# Altium schematic sheet 01_fpga.SchDoc
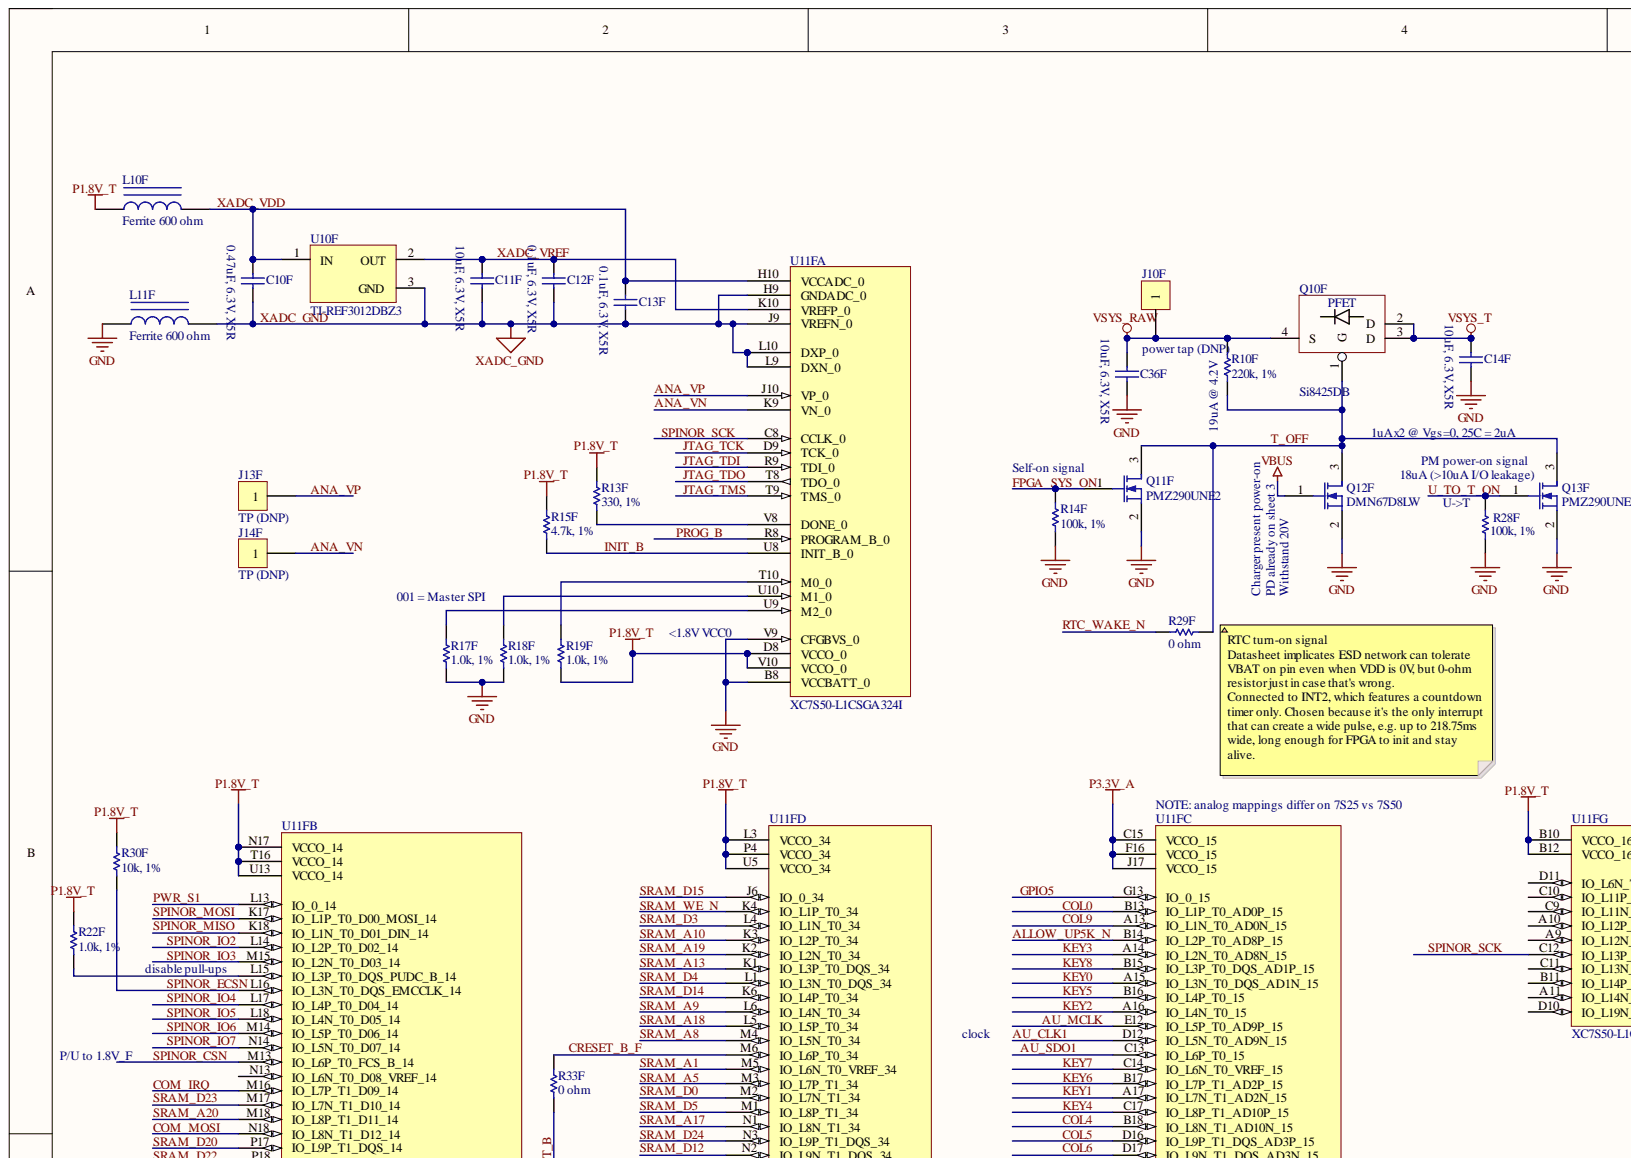
[diagram p0: 01_fpga.SchDoc, top left]
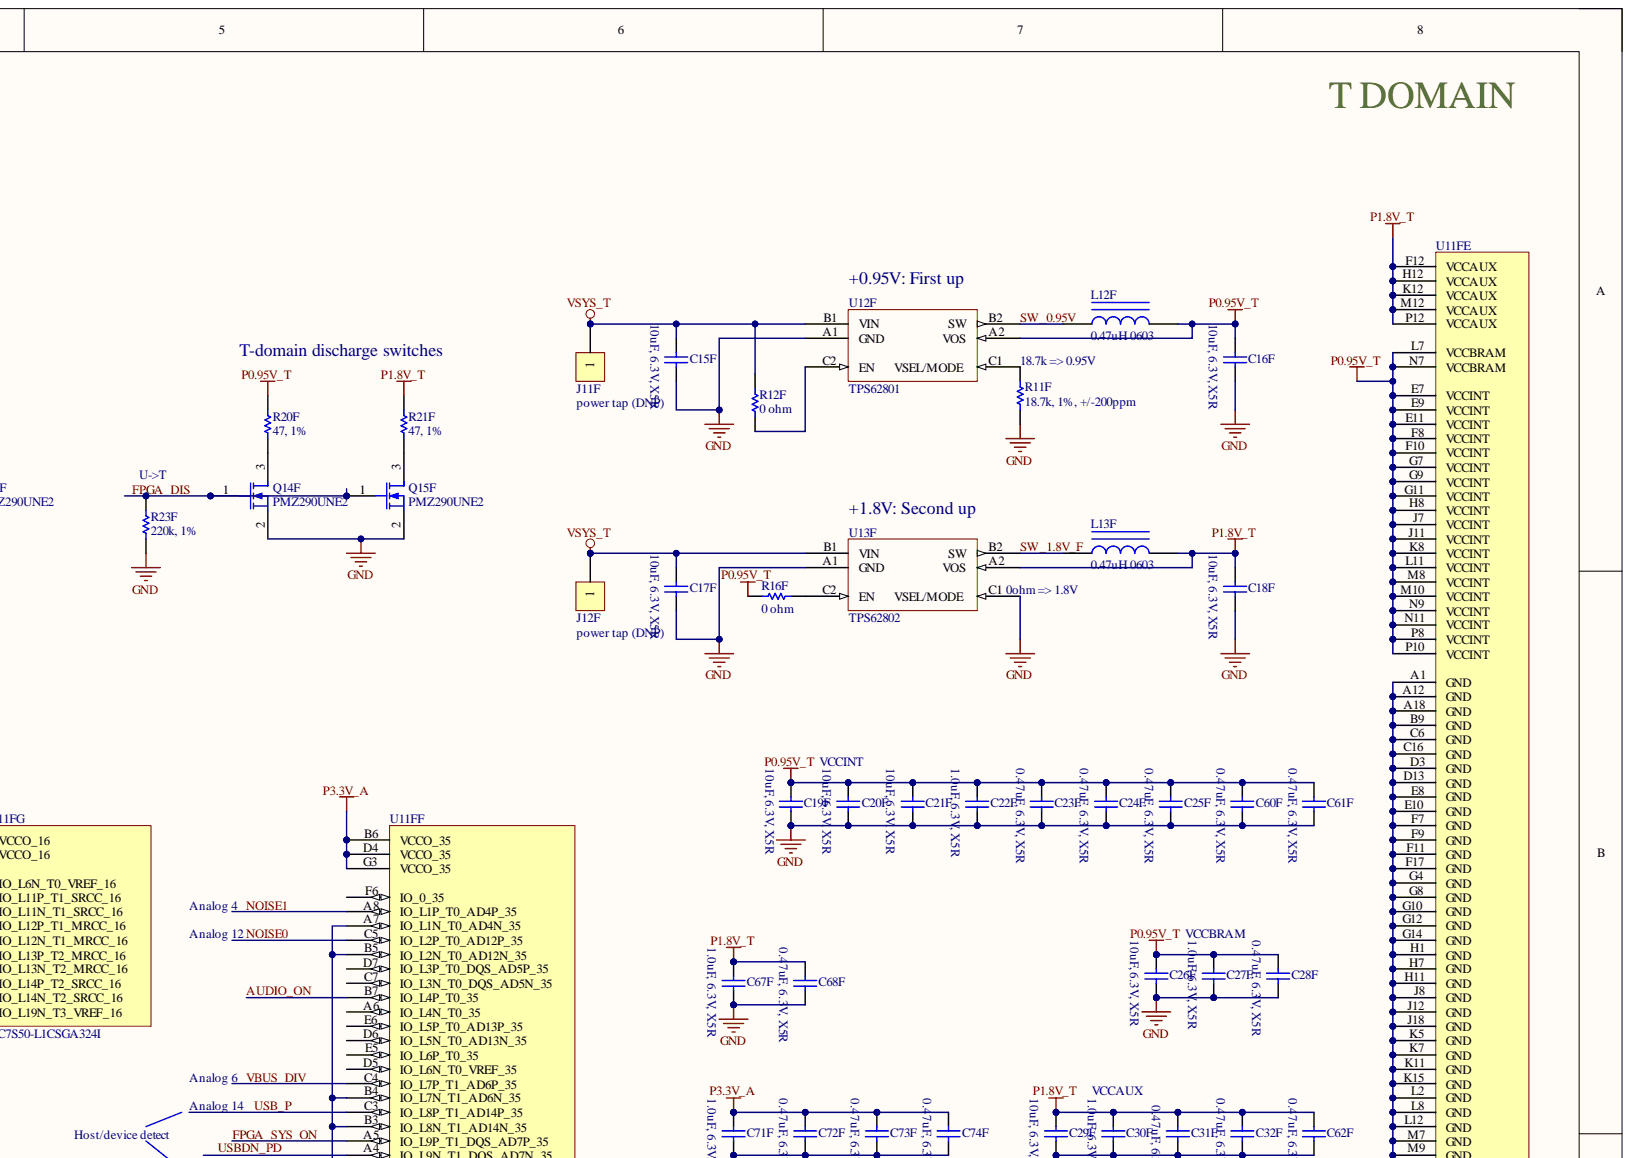
[diagram p1: 01_fpga.SchDoc, top right]
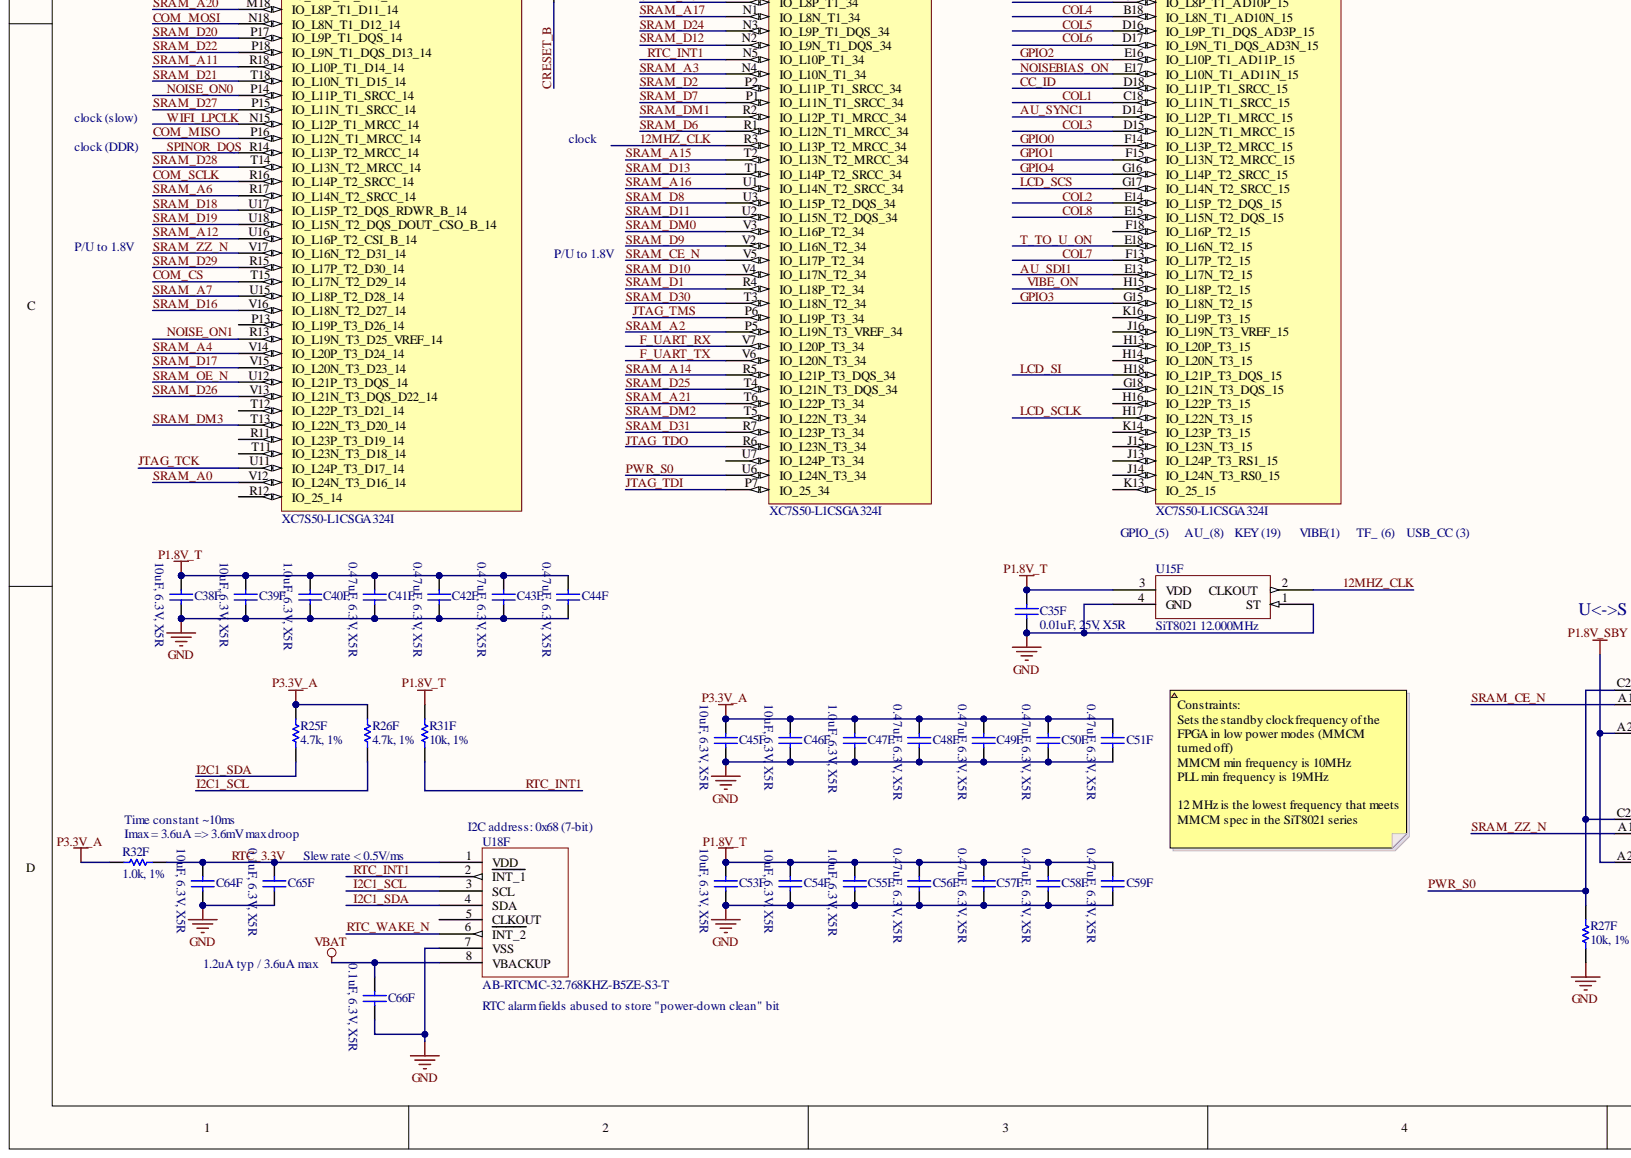
[diagram p2: 01_fpga.SchDoc, bottom left]
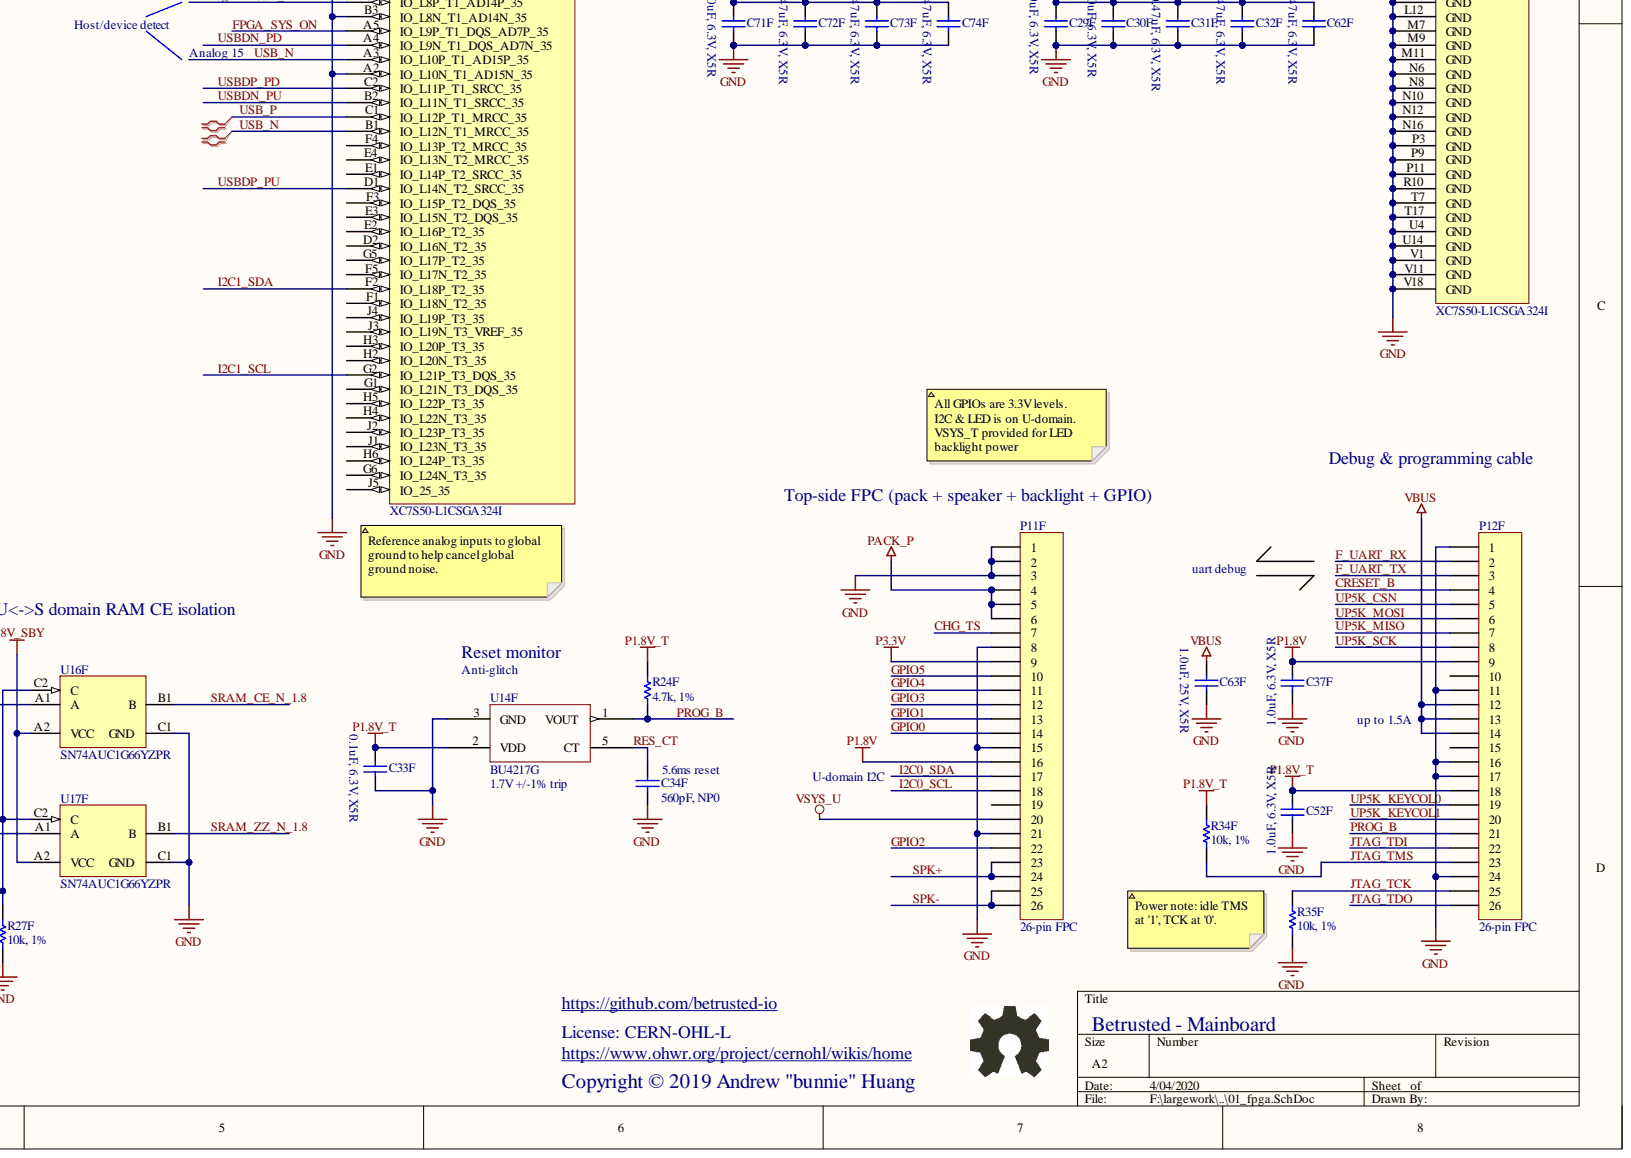
[diagram p3: 01_fpga.SchDoc, bottom right]

## Parts
C10F  0.47uF, 6.3V, X5R  [B_CAP0201]
C11F  10uF, 6.3V, X5R  [B_CAP0603]
C12F  0.1uF, 6.3V, X5R  [B_CAP0201]
C13F  0.1uF, 6.3V, X5R  [B_CAP0201]
C14F  10uF, 6.3V, X5R  [B_CAP0603]
C15F  10uF, 6.3V, X5R  [B_CAP0603]
C16F  10uF, 6.3V, X5R  [B_CAP0603]
C17F  10uF, 6.3V, X5R  [B_CAP0603]
C18F  10uF, 6.3V, X5R  [B_CAP0603]
C19F  10uF, 6.3V, X5R  [B_CAP0603]
C20F  10uF, 6.3V, X5R  [B_CAP0603]
C21F  10uF, 6.3V, X5R  [B_CAP0603]
C22F  1.0uF, 6.3V, X5R  [B_CAP0201]
C23F  0.47uF, 6.3V, X5R  [B_CAP0201]
C24F  0.47uF, 6.3V, X5R  [B_CAP0201]
C25F  0.47uF, 6.3V, X5R  [B_CAP0201]
C26F  10uF, 6.3V, X5R  [B_CAP0603]
C27F  1.0uF, 6.3V, X5R  [B_CAP0201]
C28F  0.47uF, 6.3V, X5R  [B_CAP0201]
C29F  10uF, 6.3V, X5R  [B_CAP0603]
C30F  1.0uF, 6.3V, X5R  [B_CAP0201]
C31F  0.47uF, 6.3V, X5R  [B_CAP0201]
C32F  0.47uF, 6.3V, X5R  [B_CAP0201]
C33F  0.1uF, 6.3V, X5R  [B_CAP0201]
C34F  560pF, NP0  [B_RES0201]
C35F  0.01uF, 25V, X5R  [B_RES0201]
C36F  10uF, 6.3V, X5R  [B_CAP0603]
C37F  1.0uF, 6.3V, X5R  [B_CAP0201]
C38F  10uF, 6.3V, X5R  [B_CAP0603]
C39F  10uF, 6.3V, X5R  [B_CAP0603]
C40F  1.0uF, 6.3V, X5R  [B_CAP0201]
C41F  0.47uF, 6.3V, X5R  [B_CAP0201]
C42F  0.47uF, 6.3V, X5R  [B_CAP0201]
C43F  0.47uF, 6.3V, X5R  [B_CAP0201]
C44F  0.47uF, 6.3V, X5R  [B_CAP0201]
C45F  10uF, 6.3V, X5R  [B_CAP0603]
C46F  10uF, 6.3V, X5R  [B_CAP0603]
C47F  1.0uF, 6.3V, X5R  [B_CAP0201]
C48F  0.47uF, 6.3V, X5R  [B_CAP0201]
C49F  0.47uF, 6.3V, X5R  [B_CAP0201]
C50F  0.47uF, 6.3V, X5R  [B_CAP0201]
C51F  0.47uF, 6.3V, X5R  [B_CAP0201]
C52F  1.0uF, 6.3V, X5R  [B_CAP0201]
C53F  10uF, 6.3V, X5R  [B_CAP0603]
C54F  10uF, 6.3V, X5R  [B_CAP0603]
C55F  1.0uF, 6.3V, X5R  [B_CAP0201]
C56F  0.47uF, 6.3V, X5R  [B_CAP0201]
C57F  0.47uF, 6.3V, X5R  [B_CAP0201]
C58F  0.47uF, 6.3V, X5R  [B_CAP0201]
C59F  0.47uF, 6.3V, X5R  [B_CAP0201]
C60F  0.47uF, 6.3V, X5R  [B_CAP0201]
C61F  0.47uF, 6.3V, X5R  [B_CAP0201]
C62F  0.47uF, 6.3V, X5R  [B_CAP0201]
C63F  1.0uF, 25V, X5R  [B_CAP0603]
C64F  10uF, 6.3V, X5R  [B_CAP0603]
C65F  0.1uF, 6.3V, X5R  [B_CAP0201]
C66F  0.1uF, 6.3V, X5R  [B_CAP0201]
C67F  1.0uF, 6.3V, X5R  [B_CAP0201]
C68F  0.47uF, 6.3V, X5R  [B_CAP0201]
C71F  1.0uF, 6.3V, X5R  [B_CAP0201]
C72F  0.47uF, 6.3V, X5R  [B_CAP0201]
C73F  0.47uF, 6.3V, X5R  [B_CAP0201]
C74F  0.47uF, 6.3V, X5R  [B_CAP0201]
J10F  power tap (DNP)  [RESTAP-0603]
J11F  power tap (DNP)  [RESTAP-0603]
J12F  power tap (DNP)  [RESTAP-0603]
J13F  TP (DNP)  [TP_0.4x0.4_SMT]
J14F  TP (DNP)  [TP_0.4x0.4_SMT]
L10F  Ferrite 600 ohm  [B_IND0603]
L11F  Ferrite 600 ohm  [B_IND0603]
L12F  0.47uH 0603  [B_IND0603]
L13F  0.47uH 0603  [B_IND0603]
P11F  26-pin FPC  [HRS_FH34SRJ-26S-0.5SH(50)]
P12F  26-pin FPC  [HRS_FH34SRJ-26S-0.5SH(50)]
Q10F  Si8425DB  [VISHAY_MICRO_FOOT_4_BUMP]
Q11F  PMZ290UNE2  [SOT883]
Q12F  DMN67D8LW  [SOT323-3AN]
Q13F  PMZ290UNE2  [SOT883]
Q14F  PMZ290UNE2  [SOT883]
Q15F  PMZ290UNE2  [SOT883]
R10F  220k, 1%  [B_RES0201]
R11F  18.7k, 1%, +/-200ppm  [B_RES0402]
R12F  0 ohm  [B_RES0201]
R13F  330, 1%  [B_RES0201]
R14F  100k, 1%  [B_RES0201]
R15F  4.7k, 1%  [B_RES0201]
R16F  0 ohm  [B_RES0201]
R17F  1.0k, 1%  [B_RES0201]
R18F  1.0k, 1%  [B_RES0201]
R19F  1.0k, 1%  [B_RES0201]
R20F  47, 1%  [B_RES0402]
R21F  47, 1%  [B_RES0402]
R22F  1.0k, 1%  [B_RES0201]
R23F  220k, 1%  [B_RES0201]
R24F  4.7k, 1%  [B_RES0201]
R25F  4.7k, 1%  [B_RES0201]
R26F  4.7k, 1%  [B_RES0201]
R27F  10k, 1%  [B_RES0201]
R28F  100k, 1%  [B_RES0201]
R29F  0 ohm  [B_RES0201]
R30F  10k, 1%  [B_RES0201]
R31F  10k, 1%  [B_RES0201]
R32F  1.0k, 1%  [B_RES0201]
R33F  0 ohm  [B_RES0201]
R34F  10k, 1%  [B_RES0201]
R35F  10k, 1%  [B_RES0201]
U10F  TI-REF3012DBZ3  [ONSC-SOT-23-3-318-08_V]
U11F  XC7S50-L1CSGA324I  [BGA324C80P18X18_1500X1500X150]  (parts A,B,C,D,E,F,G)
U12F  TPS62801  [BGA6C35P2X3_70X105X40_NSMD]
U13F  TPS62802  [BGA6C35P2X3_70X105X40_NSMD]
U14F  BU4217G  [SOT23-5AN]
U15F  SiT8021 12.000MHz  [SiTIME_CSP]
U16F  SN74AUC1G66YZPR  [YZP0005ADAD]
U17F  SN74AUC1G66YZPR  [YZP0005ADAD]
U18F  AB-RTCMC-32.768KHZ-B5ZE-S3-T  [ABRACON_RTCMC_LGA10]

## Nets
GND: C14F.2 C15F.2 C16F.2 C17F.2 C18F.2 C19F.2 C20F.2 C21F.2 C22F.1 C23F.2 C24F.2 C25F.2 C26F.2 C27F.1 C28F.2 C29F.2 C30F.1 C31F.2 C32F.2 C33F.2 C34F.2 C35F.2 C36F.2 C37F.1 C38F.2 C39F.2 C40F.1 C41F.2 C42F.2 C43F.2 C44F.2 C45F.2 C46F.2 C47F.1 C48F.2 C49F.2 C50F.2 C51F.2 C52F.1 C53F.2 C54F.2 C55F.1 C56F.2 C57F.2 C58F.2 C59F.2 C60F.2 C61F.2 C62F.2 C63F.2 C64F.2 C65F.2 C66F.2 C67F.1 C68F.2 C71F.1 C72F.2 C73F.2 C74F.2 L11F.1 P11F.1 P11F.2 P11F.3 P11F.8 P11F.15 P11F.21 P12F.1 P12F.11 P12F.16 P12F.17 P12F.24 Q11F.2 Q12F.2 Q13F.2 Q14F.2 Q15F.2 R11F.2 R14F.1 R17F.1 R18F.1 R23F.1 R27F.2 R28F.1 R35F.2 U11F.A1(GND) U11F.A2(IO_L10N_T1_AD15N_35) U11F.A7(IO_L1N_T0_AD4N_35) U11F.A12(GND) U11F.A18(GND) U11F.B3(IO_L8N_T1_AD14N_35) U11F.B4(IO_L7N_T1_AD6N_35) U11F.B5(IO_L2N_T0_AD12N_35) U11F.B8(VCCBATT_0) U11F.B9(GND) U11F.C6(GND) U11F.C16(GND) U11F.D3(GND) U11F.D13(GND) U11F.E8(GND) U11F.E10(GND) U11F.F7(GND) U11F.F9(GND) U11F.F11(GND) U11F.F17(GND) U11F.G4(GND) U11F.G8(GND) U11F.G10(GND) U11F.G12(GND) U11F.G14(GND) U11F.H1(GND) U11F.H7(GND) U11F.H11(GND) U11F.J8(GND) U11F.J12(GND) U11F.J18(GND) U11F.K5(GND) U11F.K7(GND) U11F.K11(GND) U11F.K15(GND) U11F.L2(GND) U11F.L8(GND) U11F.L12(GND) U11F.M7(GND) U11F.M9(GND) U11F.M11(GND) U11F.N6(GND) U11F.N8(GND) U11F.N10(GND) U11F.N12(GND) U11F.N16(GND) U11F.P3(GND) U11F.P9(GND) U11F.P11(GND) U11F.R10(GND) U11F.T7(GND) U11F.T17(GND) U11F.U4(GND) U11F.U14(GND) U11F.V1(GND) U11F.V9(CFGBVS_0) U11F.V11(GND) U11F.V18(GND) U12F.A1(GND) U13F.A1(GND) U13F.C1(VSEL/MODE) U14F.3(GND) U15F.1(ST) U15F.4(GND) U16F.C1(GND) U17F.C1(GND) U18F.7(VSS)
P0.95V_T: C16F.1 C19F.1 C20F.1 C21F.1 C22F.2 C23F.1 C24F.1 C25F.1 C26F.1 C27F.2 C28F.1 C60F.1 C61F.1 L12F.2 R16F.1 R20F.2 U11F.E7(VCCINT) U11F.E9(VCCINT) U11F.E11(VCCINT) U11F.F8(VCCINT) U11F.F10(VCCINT) U11F.G7(VCCINT) U11F.G9(VCCINT) U11F.G11(VCCINT) U11F.H8(VCCINT) U11F.J7(VCCINT) U11F.J11(VCCINT) U11F.K8(VCCINT) U11F.L7(VCCBRAM) U11F.L11(VCCINT) U11F.M8(VCCINT) U11F.M10(VCCINT) U11F.N7(VCCBRAM) U11F.N9(VCCINT) U11F.N11(VCCINT) U11F.P8(VCCINT) U11F.P10(VCCINT) U12F.A2(VOS)
P1.8V: C37F.2 P11F.16 P12F.9
P1.8V_SBY: U16F.A2(VCC) U17F.A2(VCC)
P1.8V_T: C18F.1 C29F.1 C30F.2 C31F.1 C32F.1 C33F.1 C35F.1 C38F.1 C39F.1 C40F.2 C41F.1 C42F.1 C43F.1 C44F.1 C52F.2 C53F.1 C54F.1 C55F.2 C56F.1 C57F.1 C58F.1 C59F.1 C62F.1 C67F.2 C68F.1 L10F.1 L13F.2 P12F.18 R13F.2 R15F.2 R19F.1 R21F.2 R22F.2 R24F.2 R30F.1 R31F.1 R34F.1 U11F.B10(VCCO_16) U11F.B12(VCCO_16) U11F.D8(VCCO_0) U11F.F12(VCCAUX) U11F.H12(VCCAUX) U11F.K12(VCCAUX) U11F.L3(VCCO_34) U11F.M12(VCCAUX) U11F.N17(VCCO_14) U11F.P4(VCCO_34) U11F.P12(VCCAUX) U11F.T16(VCCO_14) U11F.U5(VCCO_34) U11F.U13(VCCO_14) U11F.V10(VCCO_0) U13F.A2(VOS) U14F.2(VDD) U15F.3(VDD)
P3.3V: P11F.9
P3.3V_A: C45F.1 C46F.1 C47F.2 C48F.1 C49F.1 C50F.1 C51F.1 C71F.2 C72F.1 C73F.1 C74F.1 R25F.2 R26F.2 R32F.2 U11F.B6(VCCO_35) U11F.C15(VCCO_15) U11F.D4(VCCO_35) U11F.F16(VCCO_15) U11F.G3(VCCO_35) U11F.J17(VCCO_15)
PACK_P: P11F.4 P11F.5 P11F.6
VBAT: C66F.1 U18F.8(VBACKUP)
VBUS: C63F.1 P12F.12 P12F.13 P12F.14 Q12F.1
VSYS_RAW: C36F.1 J10F.1 Q10F.4(S) R10F.2
VSYS_T: C14F.1 C15F.1 C17F.1 J11F.1 J12F.1 Q10F.2(D) Q10F.3(D) R12F.2 U12F.B1(VIN) U13F.B1(VIN)
VSYS_U: P11F.20
12MHZ_CLK: U11F.R3(IO_L13P_T2_MRCC_34) U15F.2(CLKOUT)
ALLOW_UP5K_N: U11F.B14(IO_L2P_T0_AD8P_15)
ANA_VN: J14F.1 U11F.K9(VN_0)
ANA_VP: J13F.1 U11F.J10(VP_0)
AU_CLK1: U11F.D12(IO_L5N_T0_AD9N_15)
AU_MCLK: U11F.E12(IO_L5P_T0_AD9P_15)
AU_SDI1: U11F.E13(IO_L17N_T2_15)
AU_SDO1: U11F.C13(IO_L6P_T0_15)
AU_SYNC1: U11F.D14(IO_L12P_T1_MRCC_15)
AUDIO_ON: U11F.B7(IO_L4P_T0_35)
CC_ID: U11F.D18(IO_L11P_T1_SRCC_15)
CHG_TS: P11F.7
COL0: U11F.B13(IO_L1P_T0_AD0P_15)
COL1: U11F.C18(IO_L11N_T1_SRCC_15)
COL2: U11F.E14(IO_L15P_T2_DQS_15)
COL3: U11F.D15(IO_L12N_T1_MRCC_15)
COL4: U11F.B18(IO_L8N_T1_AD10N_15)
COL5: U11F.D16(IO_L9P_T1_DQS_AD3P_15)
COL6: U11F.D17(IO_L9N_T1_DQS_AD3N_15)
COL7: U11F.F13(IO_L17P_T2_15)
COL8: U11F.E15(IO_L15N_T2_DQS_15)
COL9: U11F.A13(IO_L1N_T0_AD0N_15)
COM_CS: U11F.T15(IO_L17N_T2_D29_14)
COM_IRQ: U11F.M16(IO_L7P_T1_D09_14)
COM_MISO: U11F.P16(IO_L12N_T1_MRCC_14)
COM_MOSI: U11F.N18(IO_L8N_T1_D12_14)
COM_SCLK: U11F.R16(IO_L14P_T2_SRCC_14)
CRESET_B: P12F.4 R33F.1
CRESET_B_F: R33F.2 U11F.M6(IO_L6P_T0_34)
F_UART_RX: P12F.2 U11F.V7(IO_L20P_T3_34)
F_UART_TX: P12F.3 U11F.V6(IO_L20N_T3_34)
FPGA_DIS: Q14F.1 Q15F.1 R23F.2
FPGA_SYS_ON: Q11F.1 R14F.2 U11F.A5(IO_L9P_T1_DQS_AD7P_35)
GPIO0: P11F.14 U11F.F14(IO_L13P_T2_MRCC_15)
GPIO1: P11F.13 U11F.F15(IO_L13N_T2_MRCC_15)
GPIO2: P11F.22 U11F.E16(IO_L10P_T1_AD11P_15)
GPIO3: P11F.12 U11F.G15(IO_L18N_T2_15)
GPIO4: P11F.11 U11F.G16(IO_L14P_T2_SRCC_15)
GPIO5: P11F.10 U11F.G13(IO_0_15)
I2C0_SCL: P11F.18
I2C0_SDA: P11F.17
I2C1_SCL: R26F.1 U11F.G2(IO_L21P_T3_DQS_35) U18F.3(SCL)
I2C1_SDA: R25F.1 U11F.F2(IO_L18P_T2_35) U18F.4(SDA)
INIT_B: R15F.1 U11F.U8(INIT_B_0)
JTAG_TCK: P12F.25 R35F.1 U11F.D9(TCK_0) U11F.U11(IO_L24P_T3_D17_14)
JTAG_TDI: P12F.22 U11F.P7(IO_25_34) U11F.R9(TDI_0)
JTAG_TDO: P12F.26 U11F.R6(IO_L23N_T3_34) U11F.T8(TDO_0)
JTAG_TMS: P12F.23 R34F.2 U11F.P6(IO_L19P_T3_34) U11F.T9(TMS_0)
KEY0: U11F.A15(IO_L3N_T0_DQS_AD1N_15)
KEY1: U11F.A17(IO_L7N_T1_AD2N_15)
KEY2: U11F.A16(IO_L4N_T0_15)
KEY3: U11F.A14(IO_L2N_T0_AD8N_15)
KEY4: U11F.C17(IO_L8P_T1_AD10P_15)
KEY5: U11F.B16(IO_L4P_T0_15)
KEY6: U11F.B17(IO_L7P_T1_AD2P_15)
KEY7: U11F.C14(IO_L6N_T0_VREF_15)
KEY8: U11F.B15(IO_L3P_T0_DQS_AD1P_15)
LCD_SCLK: U11F.H17(IO_L22N_T3_15)
LCD_SCS: U11F.G17(IO_L14N_T2_SRCC_15)
LCD_SI: U11F.H18(IO_L21P_T3_DQS_15)
NOISE0: U11F.C5(IO_L2P_T0_AD12P_35)
NOISE1: U11F.A8(IO_L1P_T0_AD4P_35)
NOISE_ON0: U11F.P14(IO_L11P_T1_SRCC_14)
NOISE_ON1: U11F.R13(IO_L19N_T3_D25_VREF_14)
NOISEBIAS_ON: U11F.E17(IO_L10N_T1_AD11N_15)
PROG_B: P12F.21 R24F.1 U11F.R8(PROGRAM_B_0) U14F.1(VOUT)
PWR_S0: R27F.1 U11F.U6(IO_L24N_T3_34) U16F.C2(C) U17F.C2(C)
PWR_S1: U11F.L13(IO_0_14)
RES_CT: C34F.1 U14F.5(CT)
RTC_3.3V: C64F.1 C65F.1 R32F.1 U18F.1(VDD)
RTC_INT1: R31F.2 U11F.N5(IO_L10P_T1_34) U18F.2(INT_1)
RTC_WAKE_N: R29F.1 U18F.6(INT_2)
SPINOR_CSN: U11F.M13(IO_L6P_T0_FCS_B_14)
SPINOR_DQS: U11F.R14(IO_L13P_T2_MRCC_14)
SPINOR_ECSN: R30F.2 U11F.L16(IO_L3N_T0_DQS_EMCCLK_14)
SPINOR_IO2: U11F.L14(IO_L2P_T0_D02_14)
SPINOR_IO3: U11F.M15(IO_L2N_T0_D03_14)
SPINOR_IO4: U11F.L17(IO_L4P_T0_D04_14)
SPINOR_IO5: U11F.L18(IO_L4N_T0_D05_14)
SPINOR_IO6: U11F.M14(IO_L5P_T0_D06_14)
SPINOR_IO7: U11F.N14(IO_L5N_T0_D07_14)
SPINOR_MISO: U11F.K18(IO_L1N_T0_D01_DIN_14)
SPINOR_MOSI: U11F.K17(IO_L1P_T0_D00_MOSI_14)
SPINOR_SCK: U11F.C8(CCLK_0) U11F.C12(IO_L13P_T2_MRCC_16)
SPK+: P11F.23 P11F.24
SPK-: P11F.25 P11F.26
SRAM_A0: U11F.V12(IO_L24N_T3_D16_14)
SRAM_A1: U11F.M5(IO_L6N_T0_VREF_34)
SRAM_A2: U11F.P5(IO_L19N_T3_VREF_34)
SRAM_A3: U11F.N4(IO_L10N_T1_34)
SRAM_A4: U11F.V14(IO_L20P_T3_D24_14)
SRAM_A5: U11F.M3(IO_L7P_T1_34)
SRAM_A6: U11F.R17(IO_L14N_T2_SRCC_14)
SRAM_A7: U11F.U15(IO_L18P_T2_D28_14)
SRAM_A8: U11F.M4(IO_L5N_T0_34)
SRAM_A9: U11F.L6(IO_L4N_T0_34)
SRAM_A10: U11F.K3(IO_L2P_T0_34)
SRAM_A11: U11F.R18(IO_L10P_T1_D14_14)
SRAM_A12: U11F.U16(IO_L16P_T2_CSI_B_14)
SRAM_A13: U11F.K1(IO_L3P_T0_DQS_34)
SRAM_A14: U11F.R5(IO_L21P_T3_DQS_34)
SRAM_A15: U11F.T2(IO_L13N_T2_MRCC_34)
SRAM_A16: U11F.U1(IO_L14N_T2_SRCC_34)
SRAM_A17: U11F.N1(IO_L8N_T1_34)
SRAM_A18: U11F.L5(IO_L5P_T0_34)
SRAM_A19: U11F.K2(IO_L2N_T0_34)
SRAM_A20: U11F.M18(IO_L8P_T1_D11_14)
SRAM_A21: U11F.T6(IO_L22P_T3_34)
SRAM_CE_N: U11F.V5(IO_L17P_T2_34) U16F.A1(A)
SRAM_CE_N_1.8: U16F.B1(B)
SRAM_D0: U11F.M2(IO_L7N_T1_34)
SRAM_D1: U11F.R4(IO_L18P_T2_34)
SRAM_D2: U11F.P2(IO_L11P_T1_SRCC_34)
SRAM_D3: U11F.L4(IO_L1N_T0_34)
SRAM_D4: U11F.L1(IO_L3N_T0_DQS_34)
SRAM_D5: U11F.M1(IO_L8P_T1_34)
SRAM_D6: U11F.R1(IO_L12N_T1_MRCC_34)
SRAM_D7: U11F.P1(IO_L11N_T1_SRCC_34)
SRAM_D8: U11F.U3(IO_L15P_T2_DQS_34)
SRAM_D9: U11F.V2(IO_L16N_T2_34)
SRAM_D10: U11F.V4(IO_L17N_T2_34)
SRAM_D11: U11F.U2(IO_L15N_T2_DQS_34)
SRAM_D12: U11F.N2(IO_L9N_T1_DQS_34)
SRAM_D13: U11F.T1(IO_L14P_T2_SRCC_34)
SRAM_D14: U11F.K6(IO_L4P_T0_34)
SRAM_D15: U11F.J6(IO_0_34)
SRAM_D16: U11F.V16(IO_L18N_T2_D27_14)
SRAM_D17: U11F.V15(IO_L20N_T3_D23_14)
SRAM_D18: U11F.U17(IO_L15P_T2_DQS_RDWR_B_14)
SRAM_D19: U11F.U18(IO_L15N_T2_DQS_DOUT_CSO_B_14)
SRAM_D20: U11F.P17(IO_L9P_T1_DQS_14)
SRAM_D21: U11F.T18(IO_L10N_T1_D15_14)
SRAM_D22: U11F.P18(IO_L9N_T1_DQS_D13_14)
SRAM_D23: U11F.M17(IO_L7N_T1_D10_14)
SRAM_D24: U11F.N3(IO_L9P_T1_DQS_34)
SRAM_D25: U11F.T4(IO_L21N_T3_DQS_34)
SRAM_D26: U11F.V13(IO_L21N_T3_DQS_D22_14)
SRAM_D27: U11F.P15(IO_L11N_T1_SRCC_14)
SRAM_D28: U11F.T14(IO_L13N_T2_MRCC_14)
SRAM_D29: U11F.R15(IO_L17P_T2_D30_14)
SRAM_D30: U11F.T3(IO_L18N_T2_34)
SRAM_D31: U11F.R7(IO_L23P_T3_34)
SRAM_DM0: U11F.V3(IO_L16P_T2_34)
SRAM_DM1: U11F.R2(IO_L12P_T1_MRCC_34)
SRAM_DM2: U11F.T5(IO_L22N_T3_34)
SRAM_DM3: U11F.T13(IO_L22N_T3_D20_14)
SRAM_OE_N: U11F.U12(IO_L21P_T3_DQS_14)
SRAM_WE_N: U11F.K4(IO_L1P_T0_34)
SRAM_ZZ_N: U11F.V17(IO_L16N_T2_D31_14) U17F.A1(A)
SRAM_ZZ_N_1.8: U17F.B1(B)
SW_0.95V: L12F.1 U12F.B2(SW)
SW_1.8V_F: L13F.1 U13F.B2(SW)
T_OFF: Q10F.1(G) Q11F.3 Q12F.3 Q13F.3 R10F.1 R29F.2
T_TO_U_ON: U11F.E18(IO_L16N_T2_15)
U_TO_T_ON: Q13F.1 R28F.2
UP5K_CSN: P12F.5
UP5K_KEYCOL0: P12F.19
UP5K_KEYCOL1: P12F.20
UP5K_MISO: P12F.7
UP5K_MOSI: P12F.6
UP5K_SCK: P12F.8
USB_N: U11F.A3(IO_L10P_T1_AD15P_35) U11F.B1(IO_L12N_T1_MRCC_35)
USB_P: U11F.C1(IO_L12P_T1_MRCC_35) U11F.C3(IO_L8P_T1_AD14P_35)
USBDN_PD: U11F.A4(IO_L9N_T1_DQS_AD7N_35)
USBDN_PU: U11F.B2(IO_L11N_T1_SRCC_35)
USBDP_PD: U11F.C2(IO_L11P_T1_SRCC_35)
USBDP_PU: U11F.D1(IO_L14N_T2_SRCC_35)
VBUS_DIV: U11F.C4(IO_L7P_T1_AD6P_35)
VIBE_ON: U11F.H15(IO_L18P_T2_15)
WIFI_LPCLK: U11F.N15(IO_L12P_T1_MRCC_14)
XADC_GND: C10F.2 C11F.2 C12F.2 C13F.2 L11F.2 U10F.3 U11F.H9(GNDADC_0) U11F.J9(VREFN_0) U11F.L9(DXN_0) U11F.L10(DXP_0)
XADC_VDD: C10F.1 C13F.1 L10F.2 U10F.1 U11F.H10(VCCADC_0)
XADC_VREF: C11F.1 C12F.1 U10F.2 U11F.K10(VREFP_0)
NetQ14F_3: Q14F.3 R20F.1
NetQ15F_3: Q15F.3 R21F.1
NetR11F_1: R11F.1 U12F.C1(VSEL/MODE)
NetR12F_1: R12F.1 U12F.C2(EN)
NetR13F_1: R13F.1 U11F.V8(DONE_0)
NetR16F_2: R16F.2 U13F.C2(EN)
NetR17F_2: R17F.2 U11F.U9(M2_0)
NetR18F_2: R18F.2 U11F.U10(M1_0)
NetR19F_2: R19F.2 U11F.T10(M0_0)
NetR22F_1: R22F.1 U11F.L15(IO_L3P_T0_DQS_PUDC_B_14)

Not connected: P11F 19; P12F 10 15; U11F A6(IO_L4N_T0_35) A9(IO_L12N_T1_MRCC_16) A10(IO_L12P_T1_MRCC_16) A11(IO_L14N_T2_SRCC_16) B11(IO_L14P_T2_SRCC_16) C7(IO_L3N_T0_DQS_AD5N_35) C9(IO_L11N_T1_SRCC_16) C10(IO_L11P_T1_SRCC_16) C11(IO_L13N_T2_MRCC_16) D2(IO_L16N_T2_35) D5(IO_L6N_T0_VREF_35) D6(IO_L5N_T0_AD13N_35) D7(IO_L3P_T0_DQS_AD5P_35) D10(IO_L19N_T3_VREF_16) D11(IO_L6N_T0_VREF_16) E1(IO_L14P_T2_SRCC_35) E2(IO_L16P_T2_35) E3(IO_L15N_T2_DQS_35) E4(IO_L13N_T2_MRCC_35) E5(IO_L6P_T0_35) E6(IO_L5P_T0_AD13P_35) F1(IO_L18N_T2_35) F3(IO_L15P_T2_DQS_35) F4(IO_L13P_T2_MRCC_35) F5(IO_L17N_T2_35) F6(IO_0_35) F18(IO_L16P_T2_15) G1(IO_L21N_T3_DQS_35) G5(IO_L17P_T2_35) G6(IO_L24N_T3_35) G18(IO_L21N_T3_DQS_15) H2(IO_L20N_T3_35) H3(IO_L20P_T3_35) H4(IO_L22N_T3_35) H5(IO_L22P_T3_35) H6(IO_L24P_T3_35) H13(IO_L20P_T3_15) H14(IO_L20N_T3_15) H16(IO_L22P_T3_15) J1(IO_L23N_T3_35) J2(IO_L23P_T3_35) J3(IO_L19N_T3_VREF_35) J4(IO_L19P_T3_35) J5(IO_25_35) J13(IO_L24P_T3_RS1_15) J14(IO_L24N_T3_RS0_15) J15(IO_L23N_T3_15) J16(IO_L19N_T3_VREF_15) K13(IO_25_15) K14(IO_L23P_T3_15) K16(IO_L19P_T3_15) N13(IO_L6N_T0_D08_VREF_14) P13(IO_L19P_T3_D26_14) R11(IO_L23P_T3_D19_14) R12(IO_25_14) T11(IO_L23N_T3_D18_14) T12(IO_L22P_T3_D21_14) U7(IO_L24P_T3_34); U18F 5(CLKOUT)

## Library data of the parts
C10F C23F C24F C25F C28F C31F C32F C41F C42F C43F C44F C48F C49F C50F C51F C56F C57F C58F C59F C60F C61F C62F C68F C72F C73F C74F = Cap Semi: Capacitor (Semiconductor SIM Model); MPN: CL03A474KQ3NNNH; MYPN: C-474-6p3-X5R-0201; VENDOR: Samsung; Component Kind: Standard; Library Name: Miscellaneous Devices.IntLib; Signal Integrity: Cap Semi; Simulation: CAP
C11F C14F C15F C16F C17F C18F C19F C20F C21F C26F C29F C36F C38F C39F C45F C46F C53F C54F C64F = Cap Semi: Capacitor (Semiconductor SIM Model); MPN: CL10A106MQ8NNNC; MYPN: C-106-6.3-X5R-0603; VENDOR: Samsung; Component Kind: Standard; Library Name: Miscellaneous Devices.IntLib; Signal Integrity: Cap Semi; Simulation: CAP
C12F C13F C33F C65F C66F = Cap Semi: Capacitor (Semiconductor SIM Model); MPN: CL03A104KQ3NNNC; MYPN: C-104-6p3-X5R-0201; VENDOR: Samsung; Component Kind: Standard; Library Name: Miscellaneous Devices.IntLib; Signal Integrity: Cap Semi; Simulation: CAP
C22F C27F C30F C37F C40F C47F C52F C55F C67F C71F = Cap Semi: Capacitor (Semiconductor SIM Model); MPN: GRM033R60J105MEA2D; MYPN: C-105-6p3-X5R-0201; VENDOR: Murata; Component Kind: Standard; Library Name: Miscellaneous Devices.IntLib; Signal Integrity: Cap Semi; Simulation: CAP
C34F = Cap Semi: Capacitor (Semiconductor SIM Model); MPN: GRM0335C1E561JA01D; MYPN: C-561-25-NP0-0201; VENDOR: Murata; Component Kind: Standard; Library Name: Miscellaneous Devices.IntLib; Signal Integrity: Cap Semi; Simulation: CAP
C35F = Cap Semi: Capacitor (Semiconductor SIM Model); MPN: GRM033R61E103KA12D; MYPN: C-103-25-X5R-0201; VENDOR: Murata; Component Kind: Standard; Library Name: Miscellaneous Devices.IntLib; Signal Integrity: Cap Semi; Simulation: CAP
C63F = Cap Semi: Capacitor (Semiconductor SIM Model); MPN: CL10A105KA8NNNC; MYPN: C-105-25-X5R-0603; VENDOR: Samsung; Component Kind: Standard; Library Name: Miscellaneous Devices.IntLib; Signal Integrity: Cap Semi; Simulation: CAP
J10F J11F J12F J13F J14F = CON1: Connector; MYPN: J-TP-PWRTAP-0603; Component Kind: Standard; MPN: DNP; VENDOR: DNP
L10F L11F = Inductor Iron: Magnetic-Core Inductor; MPN: BLM18AG601SN1D; MYPN: L-BLM18AG601SN1D; VENDOR: Murata; Component Kind: Standard; Library Name: Miscellaneous Devices.IntLib; Signal Integrity: Inductor Iron; Simulation: INDUCTOR
L12F L13F = Inductor Iron: Magnetic-Core Inductor; MPN: TFM160808ALC-R47MTAA; MYPN: L-TFM160808ALC-R47MTAA; VENDOR: TDK; Component Kind: Standard; Library Name: Miscellaneous Devices.IntLib; Signal Integrity: Inductor Iron; Simulation: INDUCTOR
P11F = FPC-26: 26 Pin FPC header; MPN: FH34SRJ-26S-0.5SH(99); MYPN: J-FPC-0.5mm-26-0.3mm-T/B; System: Connector; VENDOR: Hirose; Component Kind: Standard; Library Name: commonsch-new.SchLib
P12F = FPC-26: 26 Pin FPC header; MPN: FH34SRJ-26S-0.5SH(99); MYPN: J-FPC-0.5mm-26-0.3mm-T/B; VENDOR: Hirose; Component Kind: Standard; Library Name: commonsch-new.SchLib
Q10F = Si8425DB: P-channel 20V 6.2A 27mOhm @ -2.5V; MPN: SI8457DB-T1-E1; MYPN: Q-Si8457; VENDOR: Vishay Siliconix; Component Kind: Standard; Library Name: temp-to-merge.SchLib
Q11F Q13F Q14F Q15F = MOSFET-N: N-Channel MOSFET; MYPN: Q-PMZ290UNE2; VENDOR: Nexperia; Component Kind: Standard; LatestRevisionDate: 17-Jul-2002; LatestRevisionNote: Re-released for DXP Platform.; Library Name: Miscellaneous Devices.IntLib; MPN: PMZ290UNE2; PackageReference: E3; PackageVersion: 1995/1996; Published: 8-Jun-2000; Simulation: NMOS; Publisher: Altium Limited
Q12F = MOSFET-N: N-Channel MOSFET; MYPN: Q-DMN67D8LW; VENDOR: Diodes Inc; Component Kind: Standard; LatestRevisionDate: 17-Jul-2002; LatestRevisionNote: Re-released for DXP Platform.; Library Name: Miscellaneous Devices.IntLib; MPN: DMN67D8LW; PackageReference: E3; PackageVersion: 1995/1996; Published: 8-Jun-2000; Simulation: NMOS; Publisher: Altium Limited
R10F R23F = Res1: Resistor; MPN: ANY; MYPN: R-224-1P-1_16W-0201; Component Kind: Standard; Library Name: Miscellaneous Devices.IntLib; Signal Integrity: Res; Simulation: RESISTOR; VENDOR: ANY
R11F = Res1: Resistor; MPN: RC0402FR-0718K7L; MYPN: R-1872-1P-1_16W-0402; VENDOR: Yageo; Component Kind: Standard; Library Name: Miscellaneous Devices.IntLib; Signal Integrity: Res; Simulation: RESISTOR
R12F R16F R29F R33F = Res1: Resistor; MPN: ANY; MYPN: R-0R0-1P-1_16W-0201; Component Kind: Standard; Library Name: Miscellaneous Devices.IntLib; Signal Integrity: Res; Simulation: RESISTOR; VENDOR: ANY
R13F = Res1: Resistor; MPN: ANY; MYPN: R-331-1P-1_16W-0201; Component Kind: Standard; Library Name: Miscellaneous Devices.IntLib; Signal Integrity: Res; Simulation: RESISTOR; VENDOR: ANY
R14F R28F = Res1: Resistor; MPN: ANY; MYPN: R-104-1P-1_16W-0201; Component Kind: Standard; Library Name: Miscellaneous Devices.IntLib; Signal Integrity: Res; Simulation: RESISTOR; VENDOR: ANY
R15F R24F R25F R26F = Res1: Resistor; MPN: ANY; MYPN: R-472-1P-1_16W-0201; Component Kind: Standard; Library Name: Miscellaneous Devices.IntLib; Signal Integrity: Res; Simulation: RESISTOR; VENDOR: ANY
R17F R18F R19F R22F R32F = Res1: Resistor; MPN: ANY; MYPN: R-102-1P-1_16W-0201; Component Kind: Standard; Library Name: Miscellaneous Devices.IntLib; Signal Integrity: Res; Simulation: RESISTOR; VENDOR: ANY
R20F R21F = Res1: Resistor; MPN: ANY; MYPN: R-470-1P-1_16W-0402; Component Kind: Standard; Library Name: Miscellaneous Devices.IntLib; Signal Integrity: Res; Simulation: RESISTOR; VENDOR: ANY
R27F R30F R31F R34F R35F = Res1: Resistor; MPN: ANY; MYPN: R-103-1P-1_16W-0201; Component Kind: Standard; Library Name: Miscellaneous Devices.IntLib; Signal Integrity: Res; Simulation: RESISTOR; VENDOR: ANY
U10F = TI-REF3012DBZ3: MPN: REF3012AIDBZT; MYPN: U-REF3012AIDBZR(T/R); VENDOR: TI; Component Kind: Standard; Library Name: TI-REF3012DBZ3.SchLib
U11F = XC7S50CSGA324: Spartan 7 FPGA in CSGA324 package; MYPN: U-XC7S50-CSGA324; VENDOR: XILINX; Component Kind: Standard; Library Name: commonsch-new.SchLib; MPN: XC7S50-L1CSGA324I
U12F = TPS62801: Buck regulator, 4MHz, 0.6A, 0.8V-1.55V, low Iq; MPN: TPS62801YKAT; MYPN: U-TPS62801YKA(T/R); VENDOR: TI; Component Kind: Standard
U13F = TPS62802: Buck regulator, 4MHz, 0.6A, 1.8V-3.3V, low Iq; MPN: TPS62802YKAR; MYPN: U-TPS62802YKA(T/R); VENDOR: TI; Component Kind: Standard
U14F = BU42XXG: Low voltage free delay time setting CMOS voltage detector IC; MPN: BU4217G-TR; MYPN: U-BU4217G-TR; VENDOR: Rohm; Component Kind: Standard; Library Name: commonsch-new.SchLib
U15F = SiT8021: 1 to 26 MHz micropower oscillator; MPN: SIT8021AI-J4-18S-12.000000E; MYPN: U-SIT8021AI-J4-18S-12.000000E; VENDOR: SiTime; Component Kind: Standard
U16F U17F = CMP-0859-01029-3: Single Bilateral Analog Switch, YZP0005ADAD, LARGE T&R; Manufacturer: Texas Instruments; 3-State Output: No; Bits(#): 1; F @ Nom Voltage(Max)(Mhz): 250; ICC @ Nom Voltage(Max)(mA): 0.01; Input Type: CMOS; Logic: True; Output Drive (IOL/IOH)(Max)(mA): 9/-9; Rating: Catalog; Switch Configuration: SPST; Technology Family: AUC; tpd @ Nom Voltage(Max)(ns): 0.9,0.3,0.2,0.1; VCC(Max)(V): 2.7; VCC(Min)(V): 0.8; VENDOR: TI; Voltage(Nom)(V): 0.8,1.2,1.5,1.8,2.5; Channels(#): 1; Component Kind: Standard; DatasheetVersion: SCES386L; Generic Part Number: SN74AUC1G66; Library Name: Components - 04.06.19 - 14.52.SchLib; MPN: SN74AUC1G66YZPR; MYPN: SN74AUC1G66YZPR; Operating Temperature Range(C): -40 to 85; Output Type: CMOS; PackageReference: YZP0005ADAD; Schmitt Trigger: No; Sub-Family: Analog Switch
U18F = AB-RTCMC-32.768kHZ-B5ZE-S3: RTC Module with I2C Bus; MYPN: U-AB-RTCMC-32.768KHZ-B5ZE-S3-T; VENDOR: Abracon; Component Kind: Standard; Library Name: commonsch-new.SchLib; MPN: AB-RTCMC-32.768KHZ-B5ZE-S3-T
